# Altium schematic sheet DIYson.SchDoc(DIYson) (continued)
## Library data of the parts (continued)
U7 = 74LVC1T45W6-7: 74LVC Series 5.5 V 3-State SMT Single Bit Dual Supply Transceiver - SOT-26; Manufacturer: Diodes; Channel Type: Bidirectional; High Level Output Current: -32mA; Logic Function: Translator; Low Level Output Current: 32mA; Max Supply Voltage: 5.5V; Min Supply Voltage: 1.65V; Number of Channels: 1; Operating Supply Current: 4uA; Quiescent Current: 3uA; Case/Package: SOT-23-6; Category: Voltage Level Shifters; Component Kind: Standard; ComponentLink1Description: http://www.mouser.com/ds/2/115/74LVC1T45-318124.pdf?src-supplier=Mouser; ComponentLink1URL: http://www.mouser.com/ds/2/115/74LVC1T45-318124.pdf?src-supplier=Mouser; ComponentLink2Description: https://www.diodes.com/assets/Datasheets/74LVC1T45.pdf?src-supplier=Digi-Key; ComponentLink2URL: https://www.diodes.com/assets/Datasheets/74LVC1T45.pdf?src-supplier=Digi-Key; ComponentLink3Description: http://www.diodes.com/datasheets/74LVC1T45.pdf?src-supplier=Avnet; ComponentLink3URL: http://www.diodes.com/datasheets/74LVC1T45.pdf?src-supplier=Avnet; ComponentLink4Description: https://datasheet.octopart.com/74LVC1T45W6-7-Diodes-Inc.-datasheet-160640065.pdf?src-supplier=TME; ComponentLink4URL: https://datasheet.octopart.com/74LVC1T45W6-7-Diodes-Inc.-datasheet-160640065.pdf?src-supplier=TME; ComponentLink5Description: LCSC; ComponentLink5URL: https://www.lcsc.com/product-detail/Translators-Level-Shifters_Diodes-Incorporated-74LVC1T45W6-7_C168856.html; Contact Plating: Tin,Matte; Manufacturer Part Number: 74LVC1T45W6-7; Max Operating Temperature: 125°C; Min Operating Temperature: -40°C; Mount: Surface Mount; Number of Circuits: 1; Output Type: 3-STATE; Package Quantity: 3000; Packaging: Tape and Reel; Pricing 1: 3000=0.0479, 9000=0.0461, 15000=0.0453, 60000=0.0432, 90000=0.0419 (USD); RoHS: Yes; RoHS Compliant: Yes; Series: 74LVC; Stock 1: 219000; Supplier: LCSC; Supplier 1: Future Electronics; Supplier Part Number: C168856; Supplier Part Number 1: 4080314; Library Name: josh-lib.SchLib
U8 = WS2812B-4020: SMD,2x4mm RGB LEDs(Built-in IC) ROHS; Manufacturer: Worldsemi; Component Kind: Standard; ComponentLink1Description: LCSC; ComponentLink1URL: https://www.lcsc.com/product-detail/RGB-LEDs-Built-in-IC_Worldsemi-WS2812B-4020_C965557.html?s_z=n_ws2812; Manufacturer Part Number: WS2812B-4020; Supplier: LCSC; Supplier Part Number: C965557; Library Name: josh-lib.SchLib
